# Altium schematic sheet 08-WS2812B.SchDoc
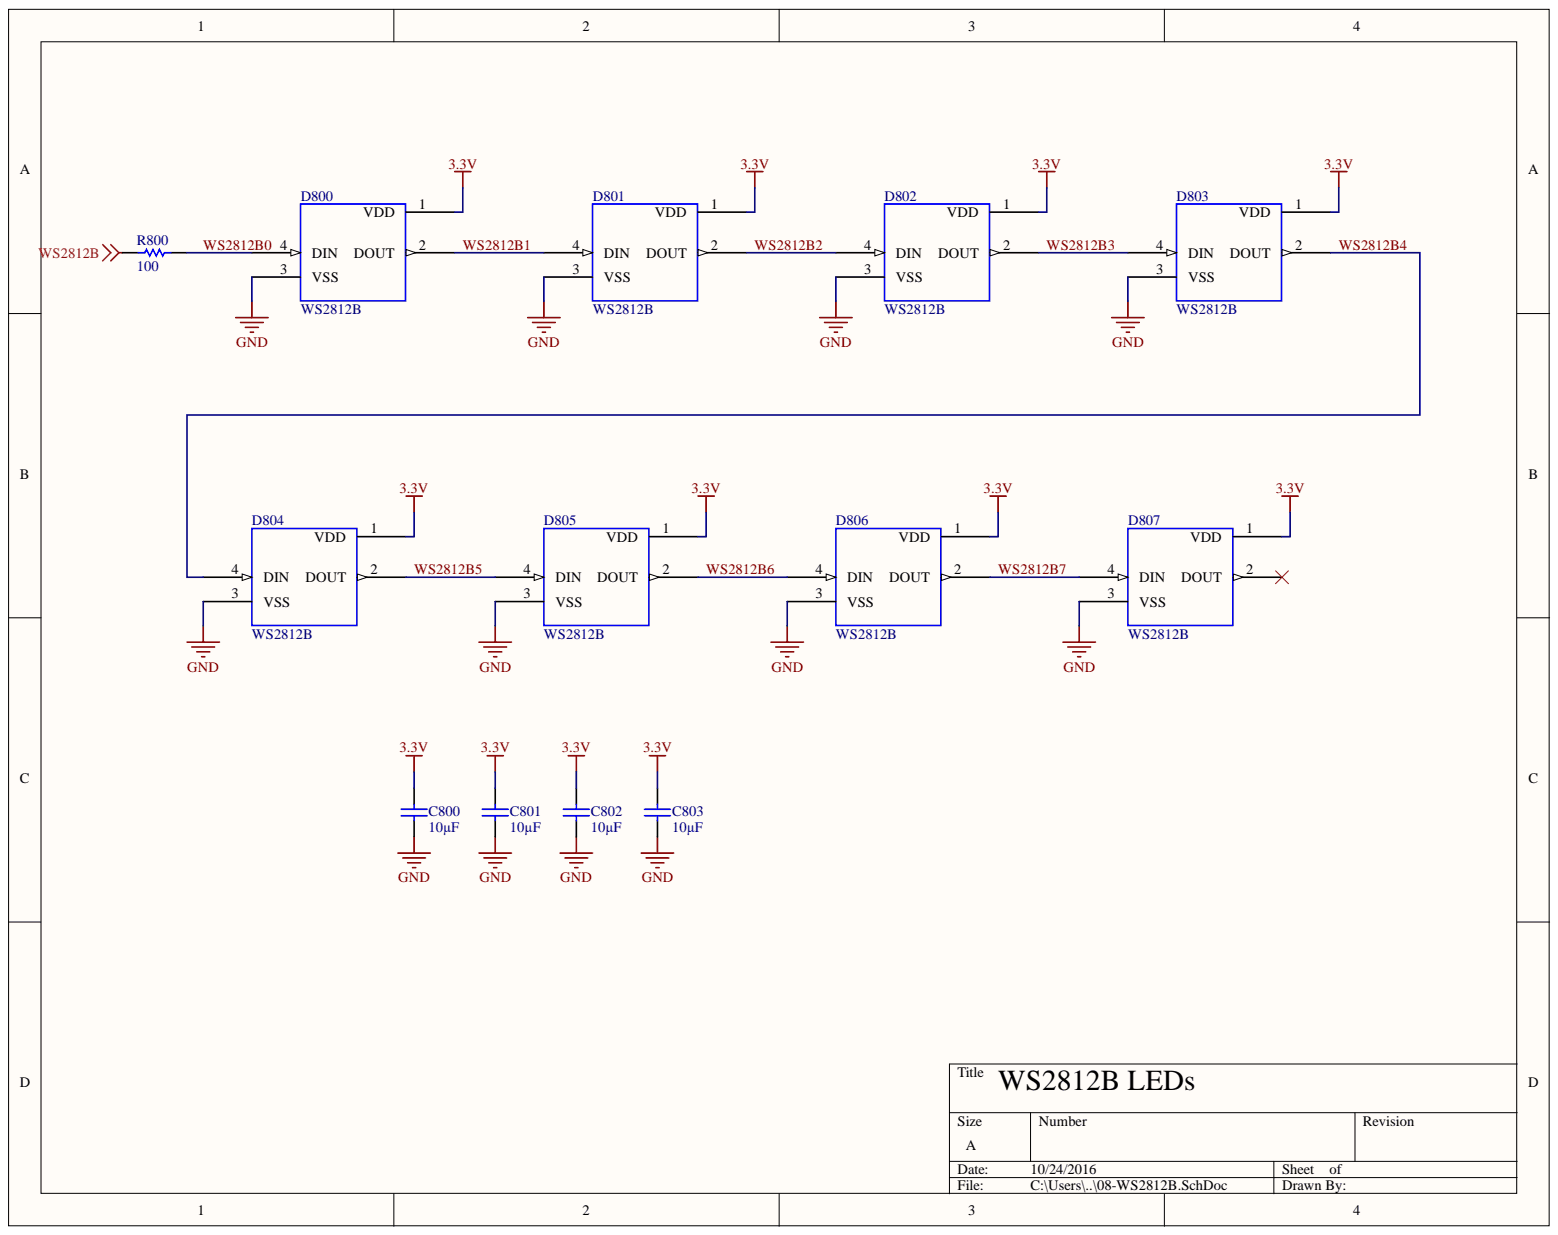
[diagram p0: 08-WS2812B.SchDoc]

## Parts
C800  10µF  [0805]
C801  10µF  [0805]
C802  10µF  [0805]
C803  10µF  [0805]
D800  WS2812B  [WS2812B]
D801  WS2812B  [WS2812B]
D802  WS2812B  [WS2812B]
D803  WS2812B  [WS2812B]
D804  WS2812B  [WS2812B]
D805  WS2812B  [WS2812B]
D806  WS2812B  [WS2812B]
D807  WS2812B  [WS2812B]
R800  100  [RES_0805]

## Nets
3.3V: C800.2 C801.2 C802.2 C803.2 D800.1(VDD) D801.1(VDD) D802.1(VDD) D803.1(VDD) D804.1(VDD) D805.1(VDD) D806.1(VDD) D807.1(VDD)
GND: C800.1 C801.1 C802.1 C803.1 D800.3(VSS) D801.3(VSS) D802.3(VSS) D803.3(VSS) D804.3(VSS) D805.3(VSS) D806.3(VSS) D807.3(VSS)
WS2812B: R800.2
WS2812B0: D800.4(DIN) R800.1
WS2812B1: D800.2(DOUT) D801.4(DIN)
WS2812B2: D801.2(DOUT) D802.4(DIN)
WS2812B3: D802.2(DOUT) D803.4(DIN)
WS2812B4: D803.2(DOUT) D804.4(DIN)
WS2812B5: D804.2(DOUT) D805.4(DIN)
WS2812B6: D805.2(DOUT) D806.4(DIN)
WS2812B7: D806.2(DOUT) D807.4(DIN)

Not connected: D807 2(DOUT)

## Library data of the parts
C800-C803 = CAP_0805_U_010.000: CAP CER 10UF 16V 10% X5R 0805; Manufacturer: Murata Electronics North America; Manufacturer Part Number: GRM21BR61C106KE15L; Applications: General Purpose; Capacitance: 10µF; Category: Capacitors; Component Kind: Standard; Features: -; Height - Seated (Max): -; Lead Spacing: -; Lead Style: -; Library Name: ece353-2016.SchLib; Mounting Type: Surface Mount, MLCC; Operating Temperature: -55°C ~ 85°C; Package / Case: 0805 (2012 Metric); Packaging: Cut Tape (CT); Ratings: -; RoHS: RoHS Compliant; Size / Dimension: 0.079" L x 0.049" W (2.00mm x 1.25mm); Supplier: Digi-Key; Supplier 1: Digi-Key; Supplier Part Number: 490-3886-1-ND; Supplier Part Number 1: 490-3886-1-ND; Temperature Coefficient: X5R; Thickness (Max): 0.053" (1.35mm); Tolerance: ±10%; Value: 10µF; Voltage - Rated: 16V
D800-D807 = WS2812B: LED RGB WS2812B; Manufacturer Part Number: EBAY; Rating: 3.5V-5.3V; Component Kind: Standard; Library Name: ece353-2016.SchLib; Value: WS2812B
R800 = RES_0805_100.00: RES 100 OHM 1/8W 1% 0805 SMD; Manufacturer: Panasonic Electronic Components; Manufacturer Part Number: ERJ-6ENF1000V; Power (Watts): 0.125W, 1/8W; Temperature Coefficient: ±100ppm/°C; Category: Resistors; Component Kind: Standard; Composition: Thick Film; Features: Automotive AEC-Q200; Height: 0.028" (0.70mm); Library Name: ece353-2016.SchLib; Number of Terminations: 2; Operating Temperature: -55°C ~ 155°C; Package / Case: 0805 (2012 Metric); Packaging: Cut Tape (CT); Resistance: 100; RoHS: RoHS Compliant; Size / Dimension: 0.079" L x 0.049" W (2.00mm x 1.25mm); Supplier: Digi-Key; Supplier 1: Digi-Key; Supplier Device Package: 0805; Supplier Part Number: P100CCT-ND; Supplier Part Number 1: P100CCT-ND; Tolerance: ±1%; Value: 100
